# Altium schematic sheet Extra6_UI.SchDoc
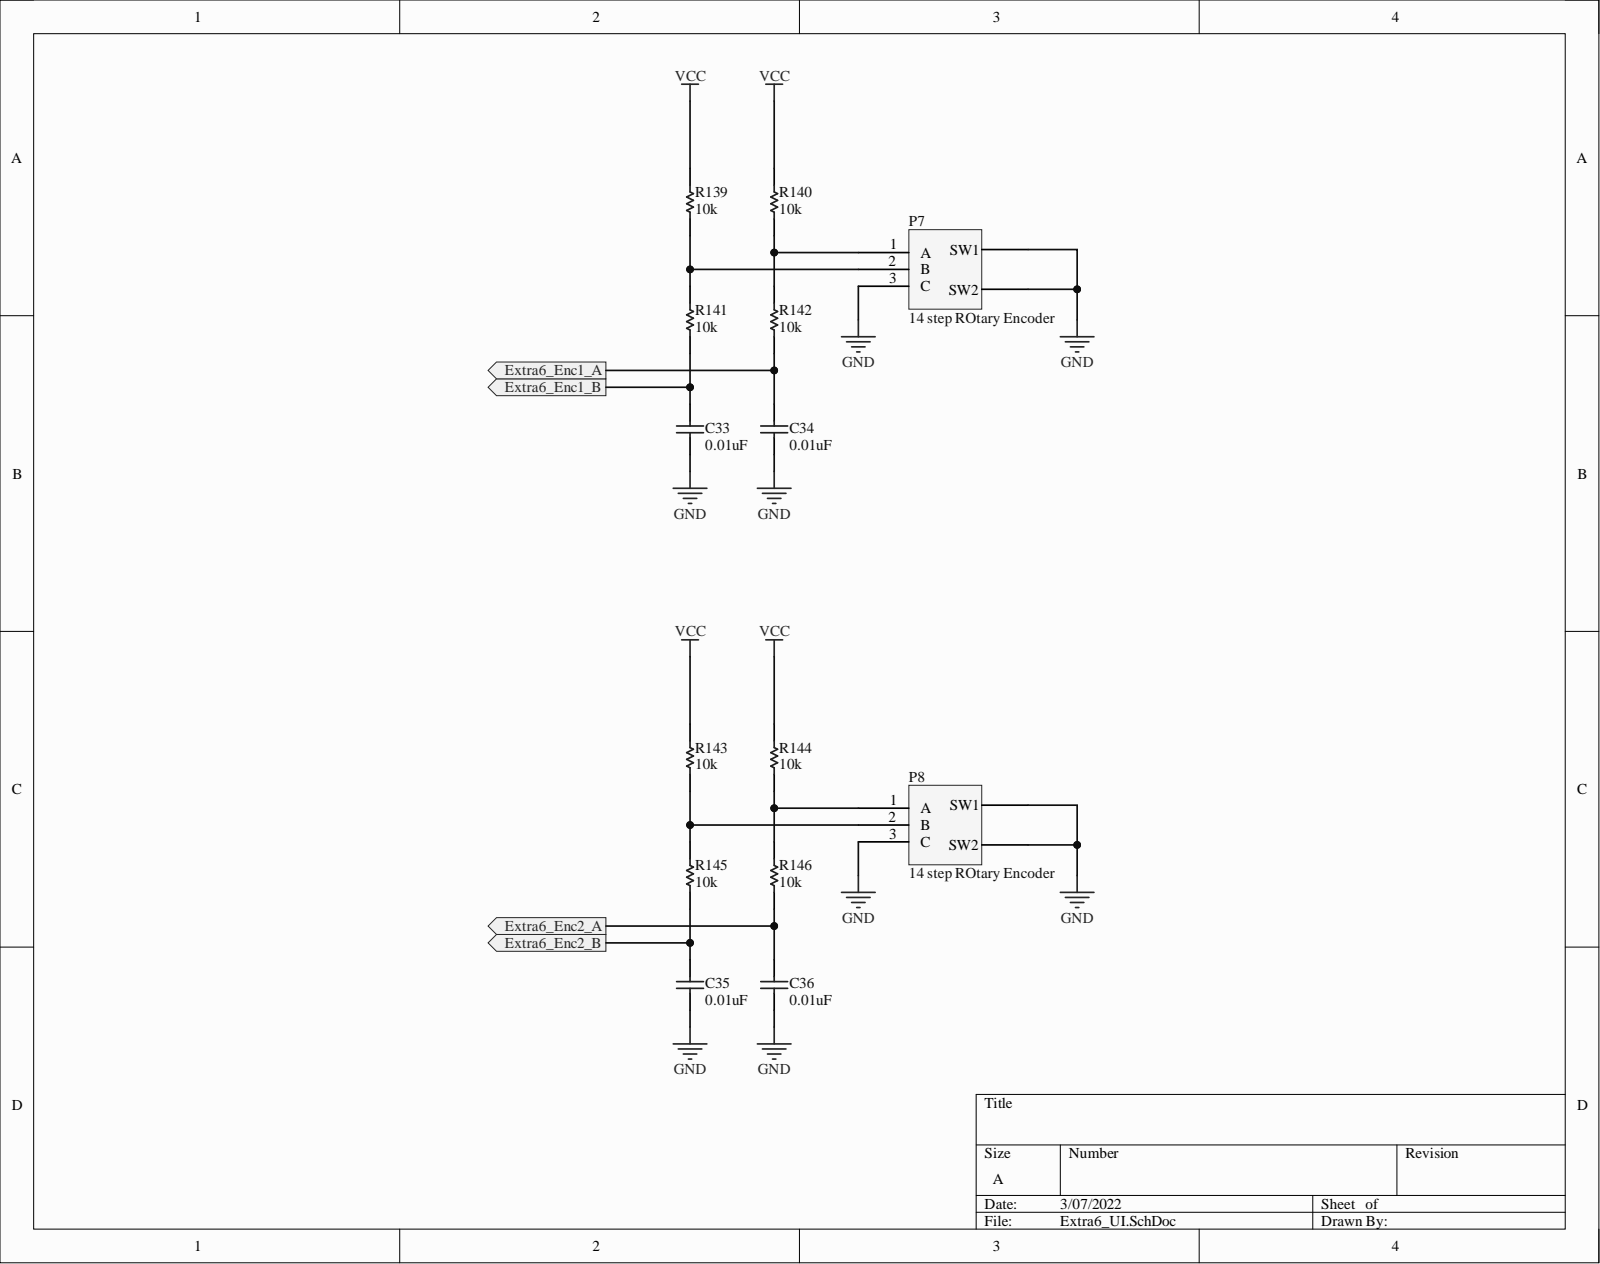
[diagram p0: Extra6_UI.SchDoc]

## Parts
C33  0.01uF  [PCB-vcjr8nxpwfuh09uwlcd6-1]
C34  0.01uF  [PCB-vcjr8nxpwfuh09uwlcd6-1]
C35  0.01uF  [PCB-vcjr8nxpwfuh09uwlcd6-1]
C36  0.01uF  [PCB-vcjr8nxpwfuh09uwlcd6-1]
P7  14 step ROtary Encoder  [PCB-x1ora0u7rhinvv961bb3-1]
P8  14 step ROtary Encoder  [PCB-x1ora0u7rhinvv961bb3-1]
R139  10k  [RESC2013X55X40NL15T20]
R140  10k  [RESC2013X55X40NL15T20]
R141  10k  [RESC2013X55X40NL15T20]
R142  10k  [RESC2013X55X40NL15T20]
R143  10k  [RESC2013X55X40NL15T20]
R144  10k  [RESC2013X55X40NL15T20]
R145  10k  [RESC2013X55X40NL15T20]
R146  10k  [RESC2013X55X40NL15T20]

## Ports
Extra6_Enc1_A, Extra6_Enc1_B, Extra6_Enc2_A, Extra6_Enc2_B

## Nets
GND: C33.1 C34.1 C35.1 C36.1 P7.3(C) P7.4(SW1) P7.5(SW2) P8.3(C) P8.4(SW1) P8.5(SW2)
VCC: R139.2 R140.2 R143.2 R144.2
Extra6_Enc1_A: C34.2 R142.1 ; port Extra6_Enc1_A
Extra6_Enc1_B: C33.2 R141.1 ; port Extra6_Enc1_B
Extra6_Enc2_A: C36.2 R146.1 ; port Extra6_Enc2_A
Extra6_Enc2_B: C35.2 R145.1 ; port Extra6_Enc2_B
NetP7_1: P7.1(A) R140.1 R142.2
NetP7_2: P7.2(B) R139.1 R141.2
NetP8_1: P8.1(A) R144.1 R146.2
NetP8_2: P8.2(B) R143.1 R145.2

## Library data of the parts
C33-C36 = CMP-526e9b1a13078da9-3: CL21 Series 10 nF 50V ±10 % Tolerance X7R SMT Multilayer Ceramic Capacitor; Manufacturer Part Number: CL21B103KBANNNC; Capacitance: 1e-08 F; Capacitance Tolerance: ±10%; Lead-Free Status: Lead Free; Voltage Rating (DC): 50.0 V; Case/Package: 0805; Component Kind: Standard; Dielectric Characteristic: X7R; Library Name: My Personal Space; Mounting Style: Surface Mount; Octopart URL: http://octopart.com/cl21b103kbannnc-samsung-8283151; OctopartID: 526e9b1a13078da9; RoHS: Compliant
P7 P8 = CMP-3ae7f27b80b4e520-3: 12 mm Incremental Encoder 24 Step; Manufacturer Part Number: PEC12R-4230F-S0024; Number of Detents: 24; Component Kind: Standard; Library Name: My Personal Space; Octopart URL: http://octopart.com/pec12r-4230f-s0024-bourns-26648373; OctopartID: 3ae7f27b80b4e520; RoHS: Compliant; Size-Length: 13.4 mm; Size-Width: 12.5 mm
R139-R146 = CMP-1953953-14: RES SMD 1M OHM 0.1% 1/8W 0805; Manufacturer Part Number: TNPW080510K0BEEN; Case/Package (SI): 2012; Lead-Free Status: Lead Free; Power Rating: 0.125 W; Resistance: 7500000 Ω; Temperature Coefficient: 25.0 ppm/°C; Voltage Rating (DC): 150.0 V; Case/Package: 0805; Component Kind: Standard; Library Name: My Personal Space; Number of Pins: 2; OctopartID: 2ed9e58acfb9f11e; Packaging: Tape & Reel (TR); Resistance Tolerance: ±0.1%; RoHS: Compliant
